# Altium schematic sheet touch.SchDoc(touch)
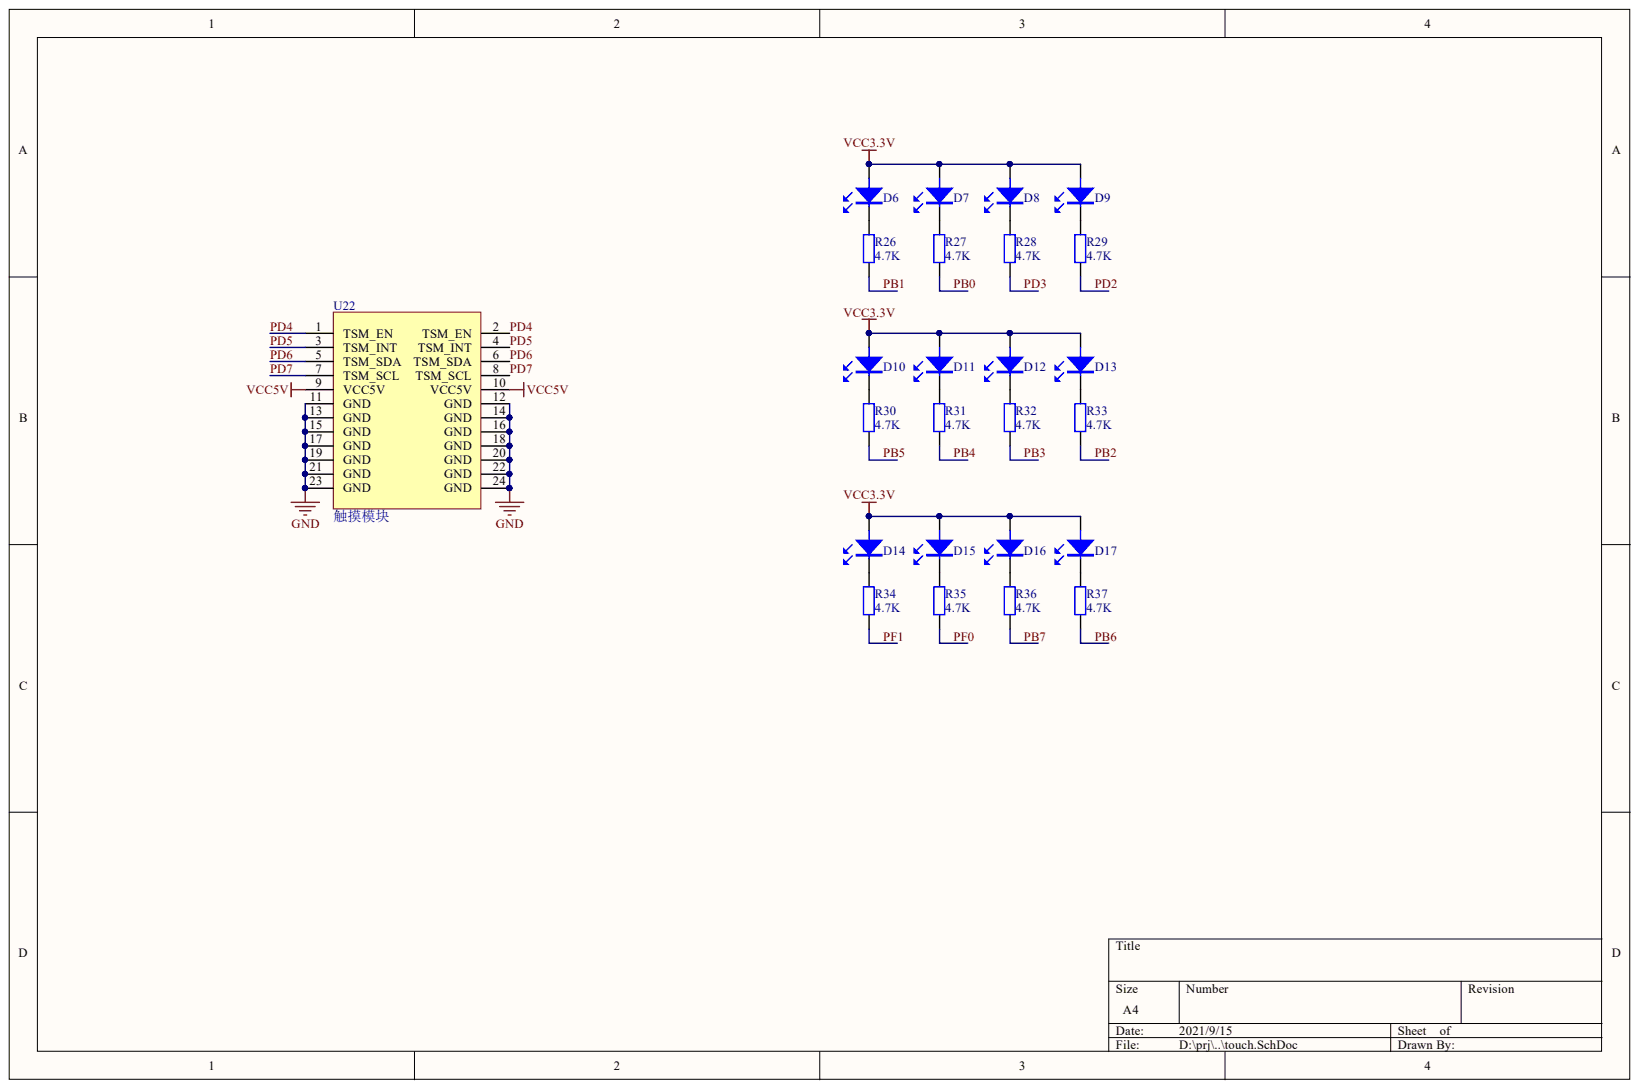
[diagram p0: touch.SchDoc]

## Parts
D6  LED  [0603_LED_S1]  (value not drawn)
D7  LED  [0603_LED_S1]  (value not drawn)
D8  LED  [0603_LED_S1]  (value not drawn)
D9  LED  [0603_LED_S1]  (value not drawn)
D10  LED  [0603_LED_S1]  (value not drawn)
D11  LED  [0603_LED_S1]  (value not drawn)
D12  LED  [0603_LED_S1]  (value not drawn)
D13  LED  [0603_LED_S1]  (value not drawn)
D14  LED  [0603_LED_S1]  (value not drawn)
D15  LED  [0603_LED_S1]  (value not drawn)
D16  LED  [0603_LED_S1]  (value not drawn)
D17  LED  [0603_LED_S1]  (value not drawn)
R26  4.7K  [0603_R]
R27  4.7K  [0603_R]
R28  4.7K  [0603_R]
R29  4.7K  [0603_R]
R30  4.7K  [0603_R]
R31  4.7K  [0603_R]
R32  4.7K  [0603_R]
R33  4.7K  [0603_R]
R34  4.7K  [0603_R]
R35  4.7K  [0603_R]
R36  4.7K  [0603_R]
R37  4.7K  [0603_R]
U22  触摸模块  [touchBoard]

## Nets
GND: U22.11(GND) U22.12(GND) U22.13(GND) U22.14(GND) U22.15(GND) U22.16(GND) U22.17(GND) U22.18(GND) U22.19(GND) U22.20(GND) U22.21(GND) U22.22(GND) U22.23(GND) U22.24(GND)
VCC3.3V: D6.A D7.A D8.A D9.A D10.A D11.A D12.A D13.A D14.A D15.A D16.A D17.A
VCC5V: U22.9(VCC5V) U22.10(VCC5V)
PB0: R27.1
PB1: R26.1
PB2: R33.1
PB3: R32.1
PB4: R31.1
PB5: R30.1
PB6: R37.1
PB7: R36.1
PD2: R29.1
PD3: R28.1
PD4: U22.1(TSM_EN) U22.2(TSM_EN)
PD5: U22.3(TSM_INT) U22.4(TSM_INT)
PD6: U22.5(TSM_SDA) U22.6(TSM_SDA)
PD7: U22.7(TSM_SCL) U22.8(TSM_SCL)
PF0: R35.1
PF1: R34.1
NetD6_K: D6.K R26.2
NetD7_K: D7.K R27.2
NetD8_K: D8.K R28.2
NetD9_K: D9.K R29.2
NetD10_K: D10.K R30.2
NetD11_K: D11.K R31.2
NetD12_K: D12.K R32.2
NetD13_K: D13.K R33.2
NetD14_K: D14.K R34.2
NetD15_K: D15.K R35.2
NetD16_K: D16.K R36.2
NetD17_K: D17.K R37.2

## Library data of the parts
D6-D17 = LED: 贴片LED，0603，绿色; Component Kind: Standard; Library Name: stm8l052r8t6开发板.SchLib
R26-R37 = Res2: 贴片电阻，0603; Component Kind: Standard; Library Name: stm8l052r8t6开发板.SchLib
U22 = touchBoard: 不焊; Component Kind: Standard; Library Name: stm8l052r8t6开发板.SchLib
